# Altium schematic sheet GPIO.SchDoc(U_GPIO_Connectors)
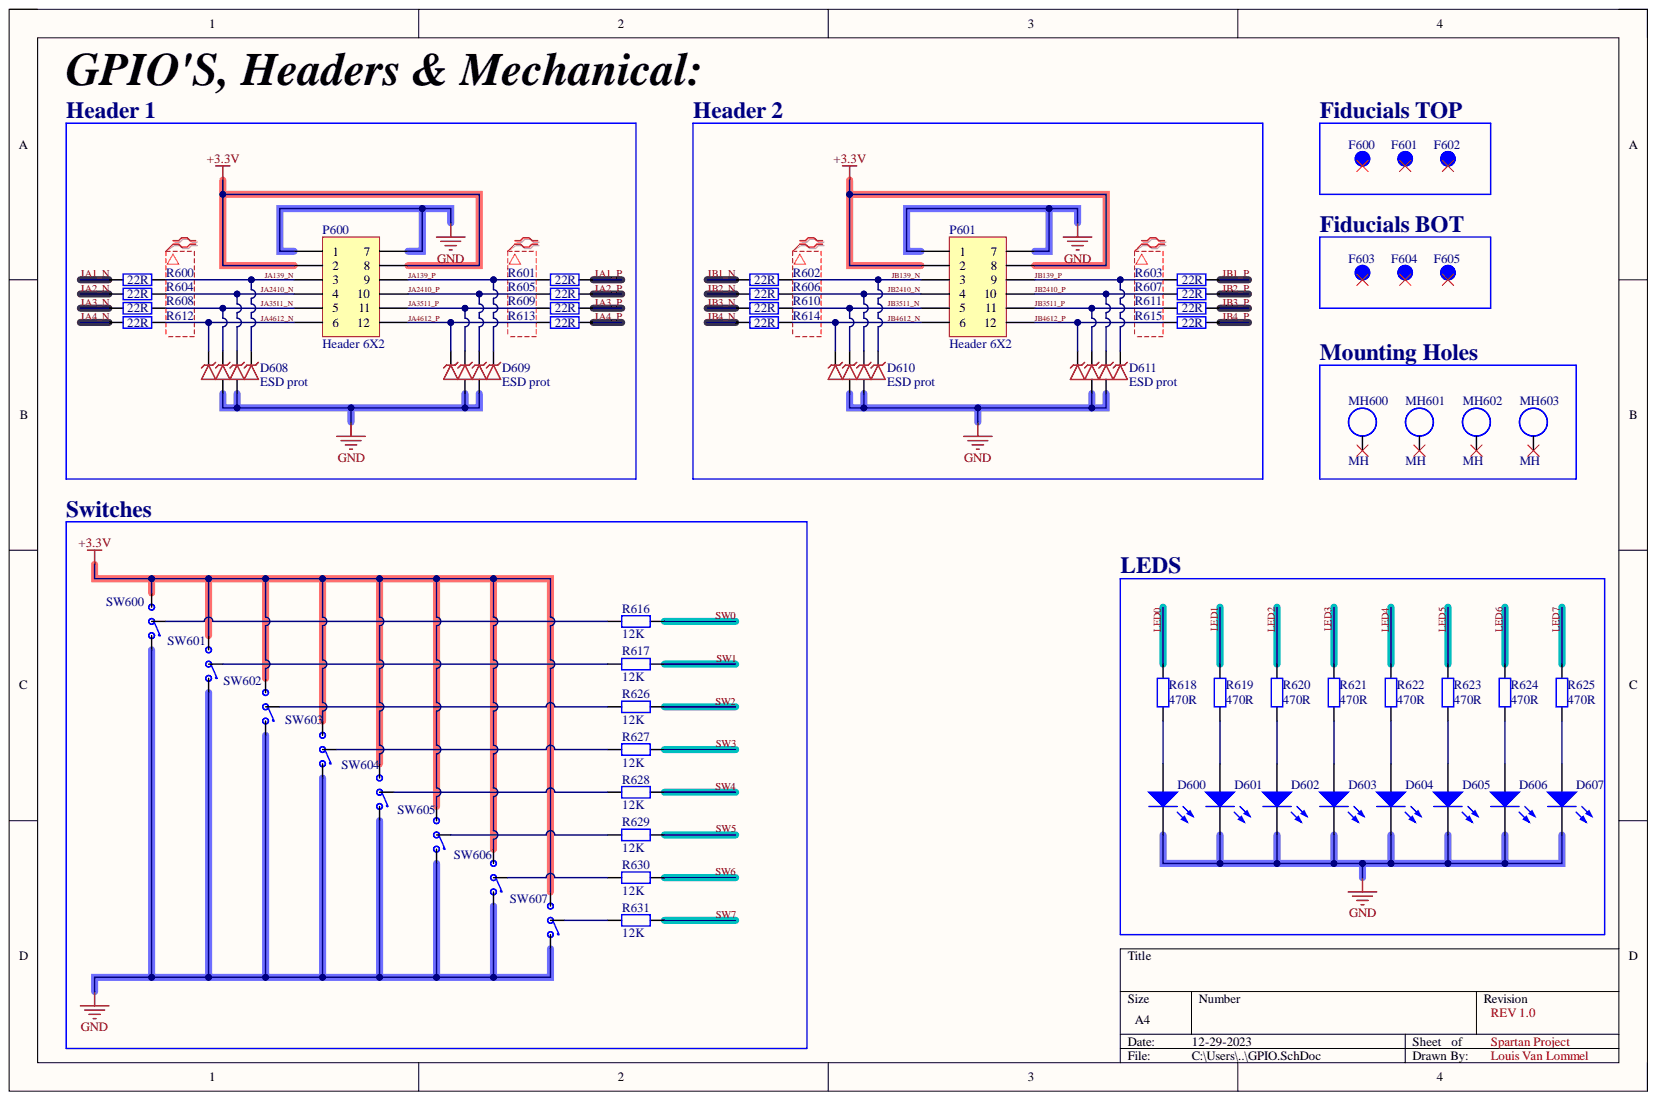
[diagram p0: GPIO.SchDoc]

## Parts
D600  LED  [LED_0603]  (value not drawn)
D601  LED  [LED_0603]  (value not drawn)
D602  LED  [LED_0603]  (value not drawn)
D603  LED  [LED_0603]  (value not drawn)
D604  LED  [LED_0603]  (value not drawn)
D605  LED  [LED_0603]  (value not drawn)
D606  LED  [LED_0603]  (value not drawn)
D607  LED  [LED_0603]  (value not drawn)
D608  ESD prot  [SOT457]
D609  ESD prot  [SOT457]
D610  ESD prot  [SOT457]
D611  ESD prot  [SOT457]
F600  Fiducial  [Fiducial30mil]  (no BOM)
F601  Fiducial  [Fiducial30mil]  (no BOM)
F602  Fiducial  [Fiducial30mil]  (no BOM)
F603  Fiducial  [Fiducial30mil]  (no BOM)
F604  Fiducial  [Fiducial30mil]  (no BOM)
F605  Fiducial  [Fiducial30mil]  (no BOM)
MH600  MH  [MH3.2mm]  (no BOM)
MH601  MH  [MH3.2mm]  (no BOM)
MH602  MH  [MH3.2mm]  (no BOM)
MH603  MH  [MH3.2mm]  (no BOM)
P600  Header 6X2  [HDR2X6_CEN]
P601  Header 6X2  [HDR2X6_CEN]
R600  22  value 22R  [RES_0603]
R601  22  value 22R  [RES_0603]
R602  22  value 22R  [RES_0603]
R603  22  value 22R  [RES_0603]
R604  22  value 22R  [RES_0603]
R605  22  value 22R  [RES_0603]
R606  22  value 22R  [RES_0603]
R607  22  value 22R  [RES_0603]
R608  22  value 22R  [RES_0603]
R609  22  value 22R  [RES_0603]
R610  22  value 22R  [RES_0603]
R611  22  value 22R  [RES_0603]
R612  22  value 22R  [RES_0603]
R613  22  value 22R  [RES_0603]
R614  22  value 22R  [RES_0603]
R615  22  value 22R  [RES_0603]
R616  12K  [RES_0603]
R617  12K  [RES_0603]
R618  470  value 470R  [RES_0603]
R619  470  value 470R  [RES_0603]
R620  470  value 470R  [RES_0603]
R621  470  value 470R  [RES_0603]
R622  470  value 470R  [RES_0603]
R623  470  value 470R  [RES_0603]
R624  470  value 470R  [RES_0603]
R625  470  value 470R  [RES_0603]
R626  12K  [RES_0603]
R627  12K  [RES_0603]
R628  12K  [RES_0603]
R629  12K  [RES_0603]
R630  12K  [RES_0603]
R631  12K  [RES_0603]
SW600  SPDT SW  [SPDT SWITCH]  (value not drawn)
SW601  SPDT SW  [SPDT SWITCH]  (value not drawn)
SW602  SPDT SW  [SPDT SWITCH]  (value not drawn)
SW603  SPDT SW  [SPDT SWITCH]  (value not drawn)
SW604  SPDT SW  [SPDT SWITCH]  (value not drawn)
SW605  SPDT SW  [SPDT SWITCH]  (value not drawn)
SW606  SPDT SW  [SPDT SWITCH]  (value not drawn)
SW607  SPDT SW  [SPDT SWITCH]  (value not drawn)

## Nets
+3.3V: P600.2 P600.8 P601.2 P601.8 SW600.1 SW601.1 SW602.1 SW603.1 SW604.1 SW605.1 SW606.1 SW607.1
GND: D600.2 D601.2 D602.2 D603.2 D604.2 D605.2 D606.2 D607.2 D608.5 D608.6 D609.5 D609.6 D610.5 D610.6 D611.5 D611.6 P600.1 P600.7 P601.1 P601.7 SW600.3 SW601.3 SW602.3 SW603.3 SW604.3 SW605.3 SW606.3 SW607.3
JA1_N: R600.2
JA1_P: R601.1
JA2_N: R604.2
JA2_P: R605.1
JA3_N: R608.2
JA3_P: R609.1
JA4_N: R612.2
JA4_P: R613.1
JA139_N: D608.4 P600.3 R600.1
JA139_P: D609.4 P600.9 R601.2
JA2410_N: D608.3 P600.4 R604.1
JA2410_P: D609.3 P600.10 R605.2
JA3511_N: D608.2 P600.5 R608.1
JA3511_P: D609.2 P600.11 R609.2
JA4612_N: D608.1 P600.6 R612.1
JA4612_P: D609.1 P600.12 R613.2
JB1_N: R602.2
JB1_P: R603.1
JB2_N: R606.2
JB2_P: R607.1
JB3_N: R610.2
JB3_P: R611.1
JB4_N: R614.2
JB4_P: R615.1
JB139_N: D610.4 P601.3 R602.1
JB139_P: D611.4 P601.9 R603.2
JB2410_N: D610.3 P601.4 R606.1
JB2410_P: D611.3 P601.10 R607.2
JB3511_N: D610.2 P601.5 R610.1
JB3511_P: D611.2 P601.11 R611.2
JB4612_N: D610.1 P601.6 R614.1
JB4612_P: D611.1 P601.12 R615.2
LED0: R618.2
LED1: R619.2
LED2: R620.2
LED3: R621.2
LED4: R622.2
LED5: R623.2
LED6: R624.2
LED7: R625.2
SW0: R616.1
SW1: R617.1
SW2: R626.1
SW3: R627.1
SW4: R628.1
SW5: R629.1
SW6: R630.1
SW7: R631.1
NetD600_1: D600.1 R618.1
NetD601_1: D601.1 R619.1
NetD602_1: D602.1 R620.1
NetD603_1: D603.1 R621.1
NetD604_1: D604.1 R622.1
NetD605_1: D605.1 R623.1
NetD606_1: D606.1 R624.1
NetD607_1: D607.1 R625.1
NetR616_2: R616.2 SW600.2
NetR617_2: R617.2 SW601.2
NetR626_2: R626.2 SW602.2
NetR627_2: R627.2 SW603.2
NetR628_2: R628.2 SW604.2
NetR629_2: R629.2 SW605.2
NetR630_2: R630.2 SW606.2
NetR631_2: R631.2 SW607.2

Not connected: F600 1; F601 1; F602 1; F603 1; F604 1; F605 1; MH600 1; MH601 1; MH602 1; MH603 1

## Library data of the parts
D600-D607 = LED0: Typical INFRARED GaAs LED; Component Kind: Standard; LatestRevisionDate: 08-Jul-2005; LatestRevisionNote: Mech Layer 1 removed.; Library Name: Miscellaneous Devices.IntLib; PackageDescription: LED; 2 Leads; PackageReference: LED-0; Published: 8-Jun-2000; Simulation: LED0; Publisher: Altium Limited
D608-D611 = ESD prot: NEXPERIA BZA462A,125; Component Kind: Standard; Library Name: Schematic_Symbols.SchLib
F600-F605 = Fiducial: 30mil Fiducial; Library Name: Schematic_Symbols.SchLib
MH600-MH603 = MH: 3.2mm mounting hole; Library Name: Schematic_Symbols.SchLib
P600 P601 = Header 6X2A: Header, 6-Pin, Dual row; Component Kind: Standard; LatestRevisionDate: 17-Jul-2002; LatestRevisionNote: Re-released for DXP Platform.; Library Name: Miscellaneous Connectors.IntLib; Signal Integrity: Connector; Publisher: Altium Limited
R600-R631 = Res2: Resistor; Component Kind: Standard; LatestRevisionDate: 17-Jul-2002; LatestRevisionNote: Re-released for DXP Platform.; Library Name: Miscellaneous Devices.IntLib; PackageDescription: Axial Device, Thru-Hole; 2 Leads; 0.4 in Pin Spacing; PackageReference: AXIAL-0.4; Published: 8-Jun-2000; Signal Integrity: Res; Simulation: RESISTOR; Publisher: Altium Limited
  R616 R617 R626-R631: Value: 12K
SW600-SW607 = SPDT SW: Component Kind: Standard; Library Name: Schematic_Symbols.SchLib
